# Altium schematic sheet Power_High.SchDoc
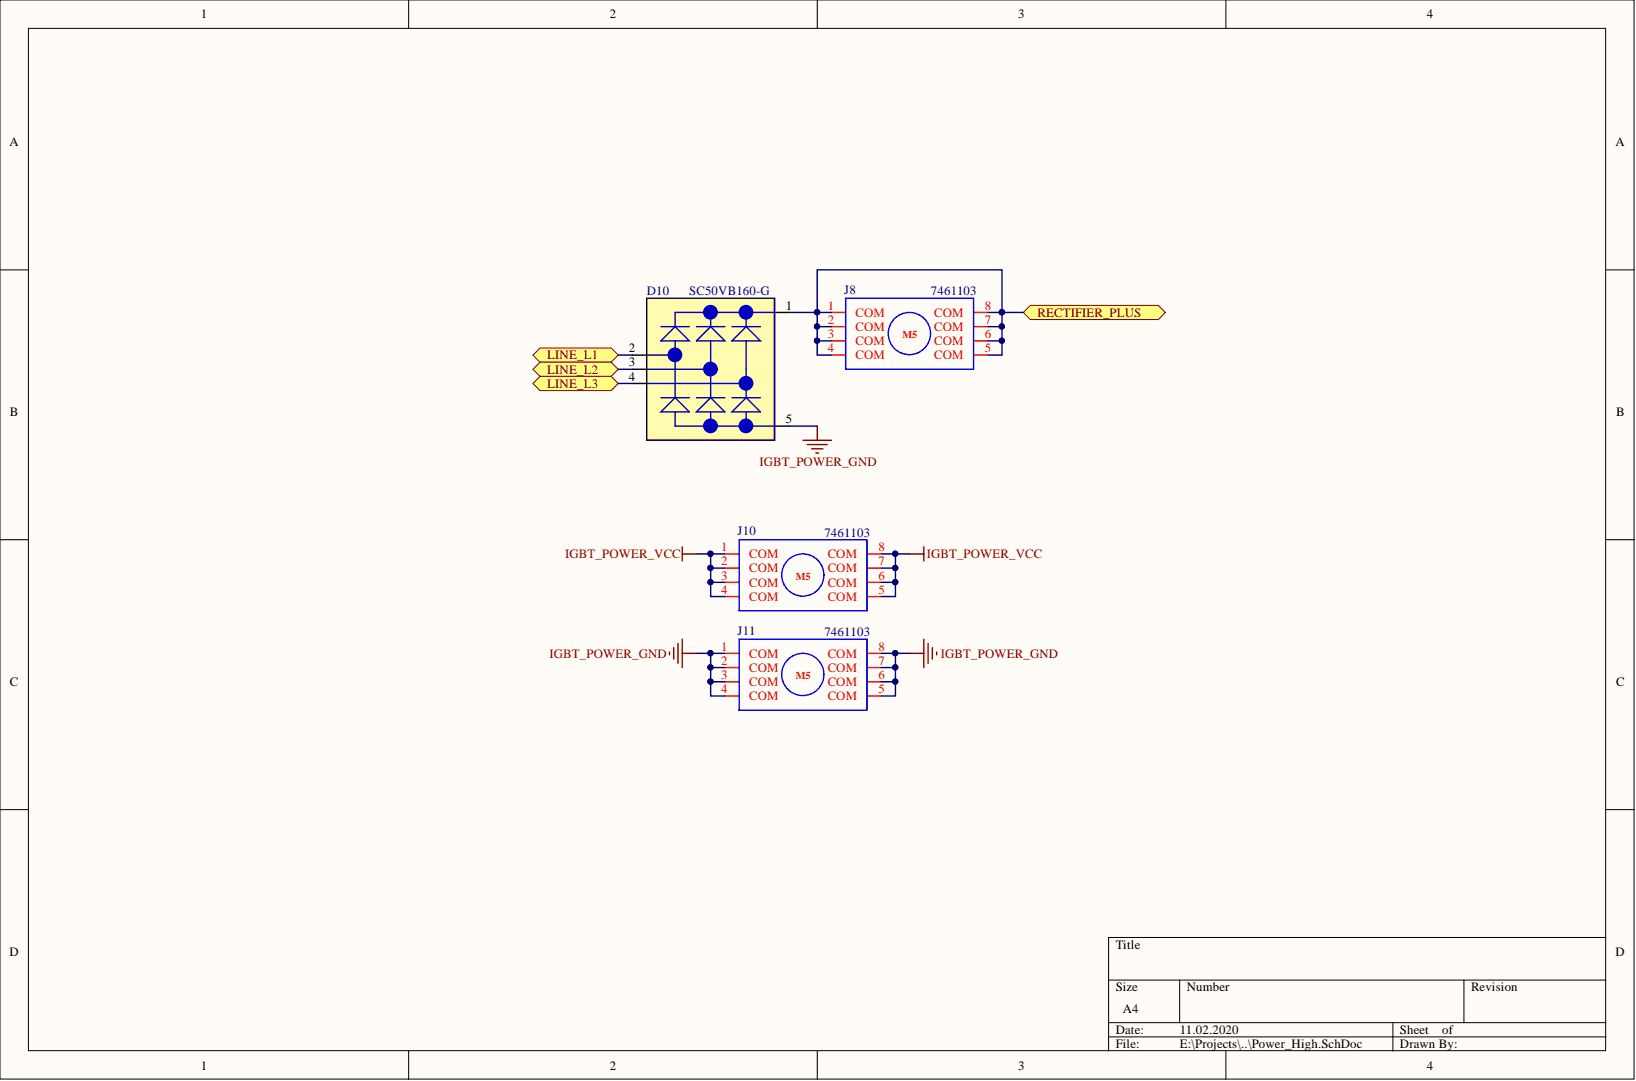
[diagram p0: Power_High.SchDoc]

## Parts
D10  SC50VB160-G  [SCVB]
J8  7461103  [7461103]
J10  7461103  [7461103]
J11  7461103  [7461103]

## Ports
LINE_L1, LINE_L2, LINE_L3, RECTIFIER_PLUS

## Nets
IGBT_POWER_GND: D10.5 J11.1(COM) J11.2(COM) J11.3(COM) J11.4(COM) J11.5(COM) J11.6(COM) J11.7(COM) J11.8(COM)
IGBT_POWER_VCC: J10.1(COM) J10.2(COM) J10.3(COM) J10.4(COM) J10.5(COM) J10.6(COM) J10.7(COM) J10.8(COM)
LINE_L1: D10.2 ; port LINE_L1
LINE_L2: D10.3 ; port LINE_L2
LINE_L3: D10.4 ; port LINE_L3
RECTIFIER_PLUS: D10.1 J8.1(COM) J8.2(COM) J8.3(COM) J8.4(COM) J8.5(COM) J8.6(COM) J8.7(COM) J8.8(COM) ; port RECTIFIER_PLUS

## Library data of the parts
D10 = SC50VB160-G: Glass Passivated Bridge Rectifiers; Component Kind: Standard; Library Name: Diode.SchLib; Value: SC50VB160-G
J8 J10 J11 = 7461103: TERM PWR ELEMENT M5 8 PIN PCB RA; System: Connector; Component Kind: Standard; Library Name: Connectors.SchLib; Value: 7461103
